# Altium schematic sheet RN4871_ON_CP2104.SchDoc
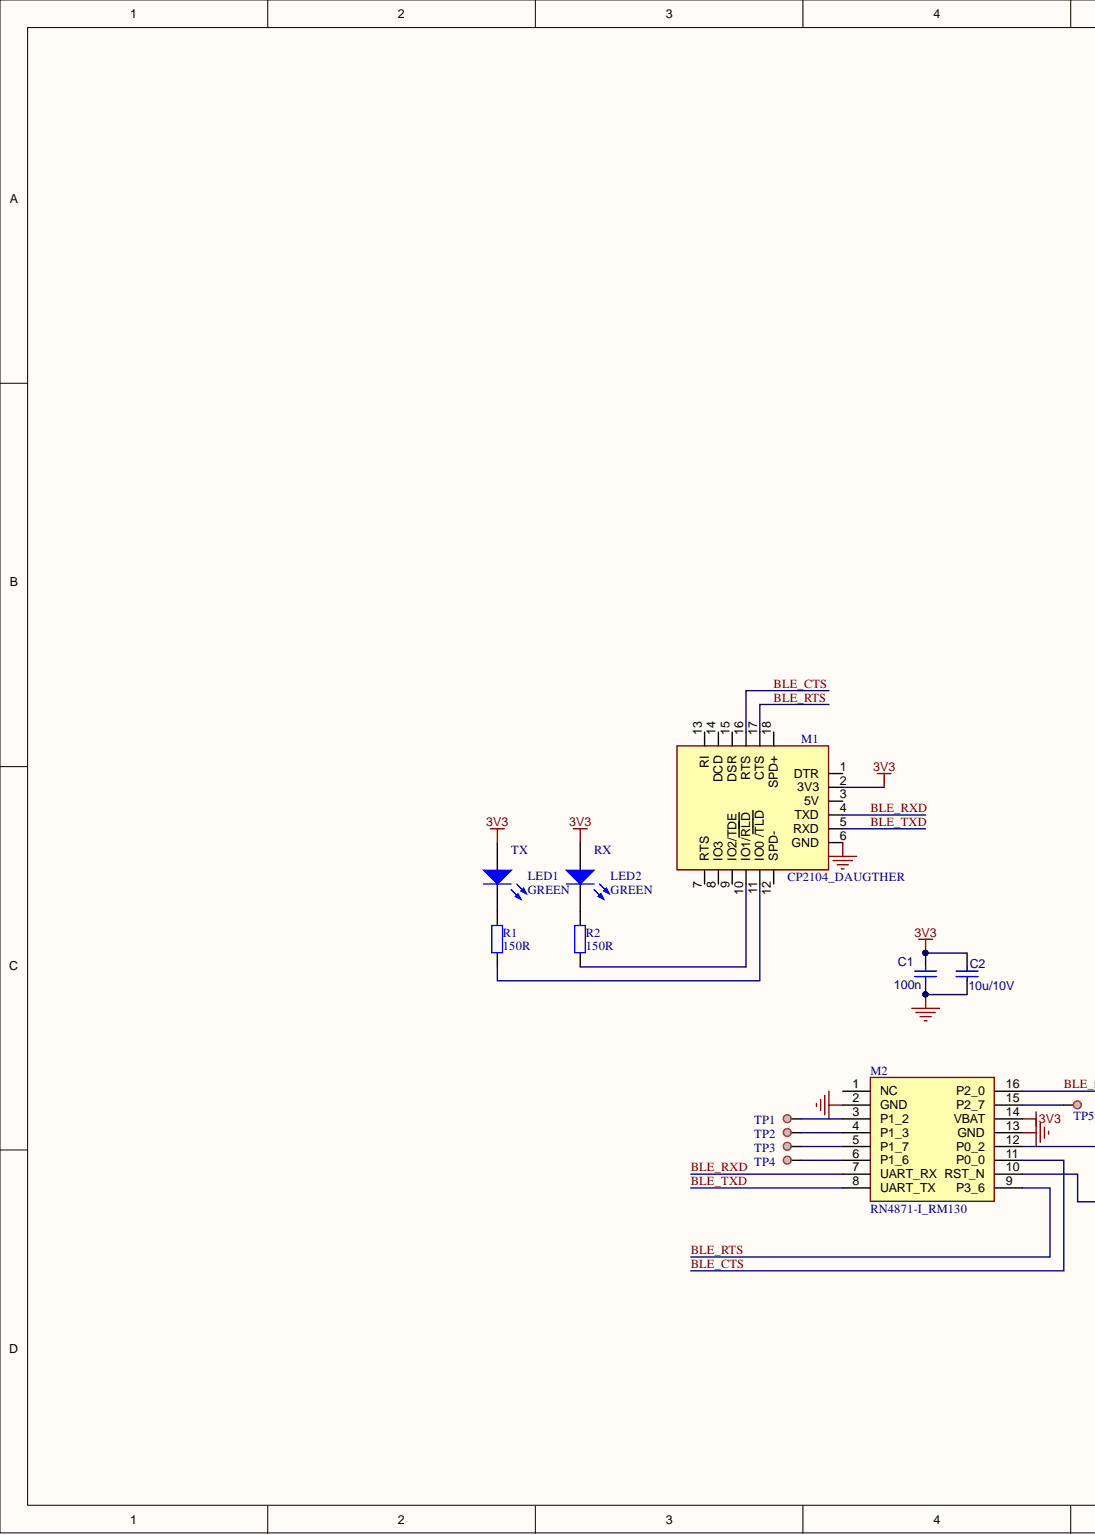
[diagram p0: RN4871_ON_CP2104.SchDoc, left]
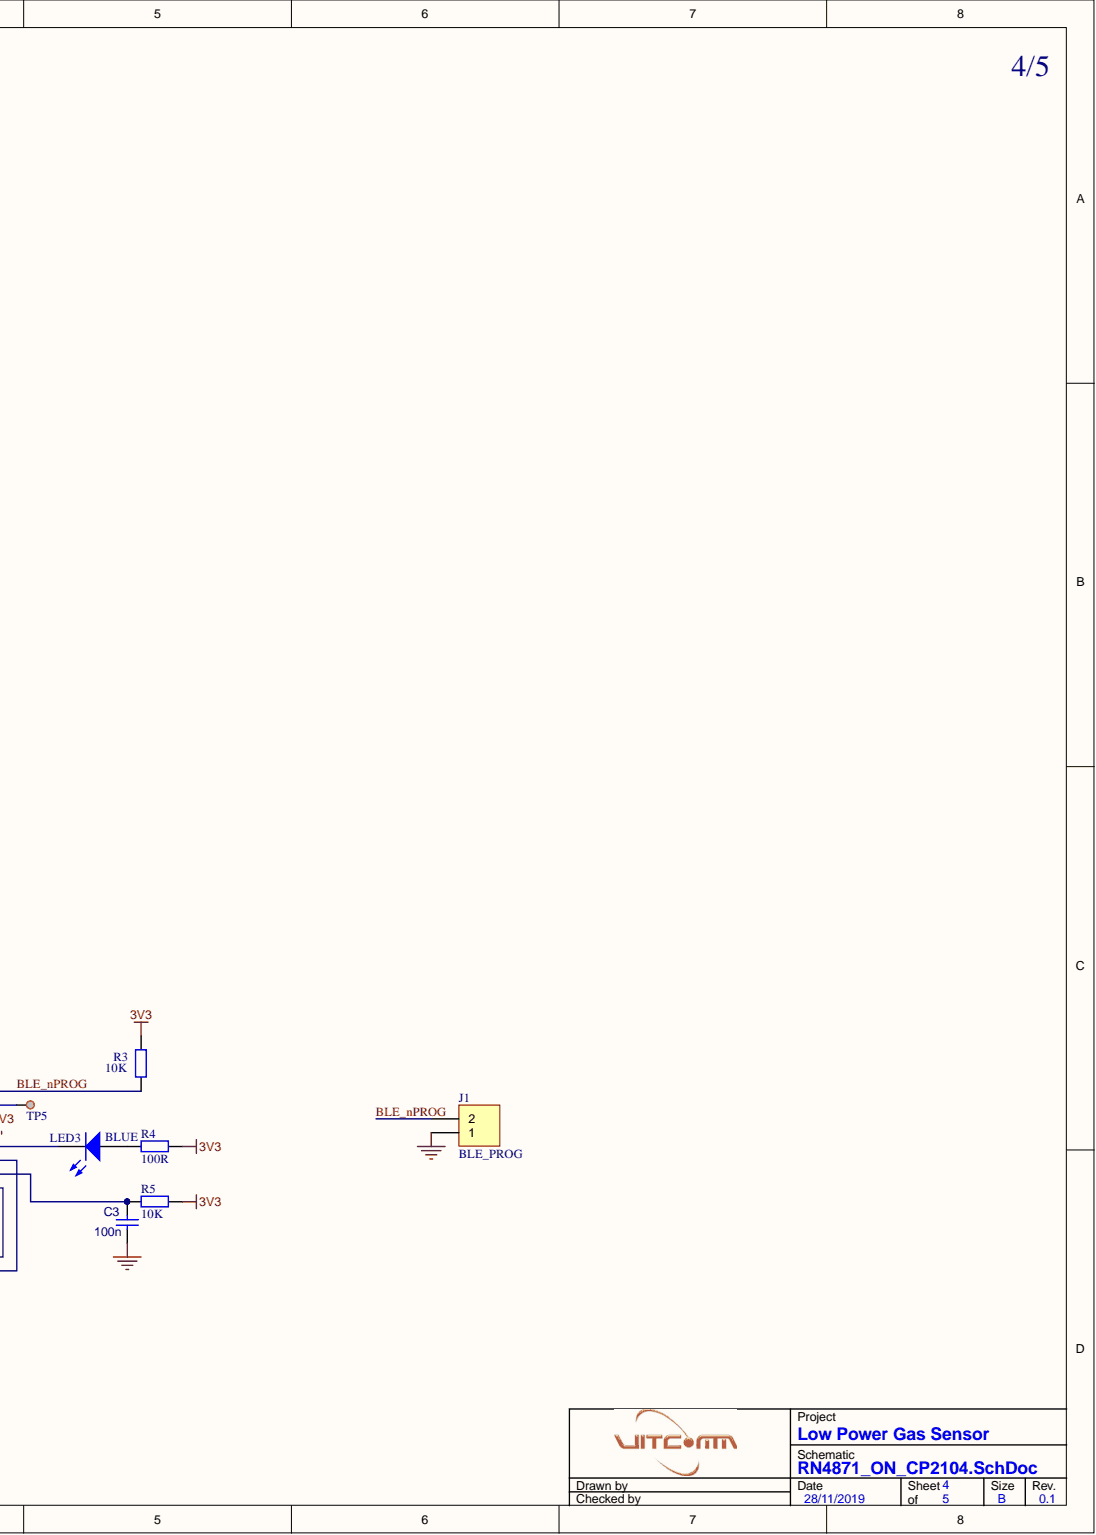
[diagram p1: RN4871_ON_CP2104.SchDoc, right]

## Parts
C1  100n  [0603N]
C2  10u/10V  [0805N]
C3  100n  [0603N]
J1  BLE_PROG  [HDR1X2]
LED1  GREEN  [LED_0603_A1K2]
LED2  GREEN  [LED_0603_A1K2]
LED3  BLUE  [LED_0603_A1K2]
M1  CP2104_DAUGTHER  [MODULE_CP2104_Daughter]
M2  RN4871-I_RM130  [RN4871]
R1  150R  [0603N]
R2  150R  [0603N]
R3  10K  [0603N]
R4  100R  [0603N]
R5  10K  [0603N]
TP1  TP  [TP_Small]
TP2  TP  [TP_Small]
TP3  TP  [TP_Small]
TP4  TP  [TP_Small]
TP5  TP  [TP_Small]

## Nets
3V3: C1.2 C2.2 LED1.1 LED2.1 M1.2(3V3) M2.14(VBAT) R3.1 R4.1 R5.2
GND: C1.1 C2.1 C3.2 J1.1 M1.6(GND) M2.2(GND) M2.13(GND)
BLE_CTS: M1.16(RTS) M2.11(P0_0)
BLE_nPROG: J1.2 M2.16(P2_0) R3.2
BLE_RTS: M1.17(CTS) M2.9(P3_6)
BLE_RXD: M1.4(TXD) M2.7(UART_RX)
BLE_TXD: M1.5(RXD) M2.8(UART_TX)
NetC3_1: C3.1 M2.10(RST_N) R5.1
NetLED1_2: LED1.2 R1.1
NetLED2_2: LED2.2 R2.1
NetLED3_1: LED3.1 R4.2
NetLED3_2: LED3.2 M2.12(P0_2)
NetM1_10: M1.10(IO1/RLD) R2.2
NetM1_11: M1.11(IO0_/TLD) R1.2
NetM2_3: M2.3(P1_2) TP1.1
NetM2_4: M2.4(P1_3) TP2.1
NetM2_5: M2.5(P1_7) TP3.1
NetM2_6: M2.6(P1_6) TP4.1
NetM2_15: M2.15(P2_7) TP5.1

Not connected: M1 1(DTR) 3(5V) 7(RTS) 8(IO3) 9(IO2/TDE) 12(SPD-) 13(RI) 14(DCD) 15(DSR) 18(SPD+); M2 1(NC)

## Library data of the parts
C1-C3 = cap: Capacitor; Value: 100pF; Component Kind: Standard; LatestRevisionDate: 17-Jul-2002; LatestRevisionNote: Re-released for DXP Platform.; Library Name: Miscellaneous Devices.IntLib; PackageDescription: Radial Cap, Thru-Hole; 2 Leads; 0.3 in Pin Spacing; PackageReference: RAD-0.3; Published: 8-Jun-2000; Publisher: Altium Limited
J1 = Header 2: Header, 2-Pin; Component Kind: Standard; LatestRevisionDate: 17-Jul-2002; LatestRevisionNote: Re-released for DXP Platform.; Library Name: Miscellaneous Connectors.IntLib; Signal Integrity: Connector; Publisher: Altium Limited
LED1-LED3 = LED_1A_2K: LED; Component Kind: Standard; Library Name: MYSCH.SchLib
M1 = Module_CP2104_DAUGHTER: CP2104 daughter board; Component Kind: Standard; Library Name: MYSCH.SchLib
M2 = RN4871-I_RM128: Integrated Circuit; Manufacturer_Name: Microchip; Manufacturer_Part_Number: RN4871-I/RM128; Component Kind: Standard; Datasheet Link: http://ww1.microchip.com/downloads/en/DeviceDoc/50002489C.pdf; Library Name: SamacSys.SchLib; Mouser Part Number: 579-RN4871-I/RM128; Mouser Price/Stock: https://www.mouser.com/Search/Refine.aspx?Keyword=579-RN4871-I%2FRM128; RS Part Number: 1372666P; RS Price/Stock: http://uk.rs-online.com/web/p/products/1372666P
R1-R5 = Res2: Resistor; Component Kind: Standard; Library Name: Miscellaneous Devices.IntLib
TP1-TP5 = TP: Testpoint; Component Kind: Standard; Library Name: MYSCH.SchLib
